# Altium schematic sheet 6_Axis.SchDoc
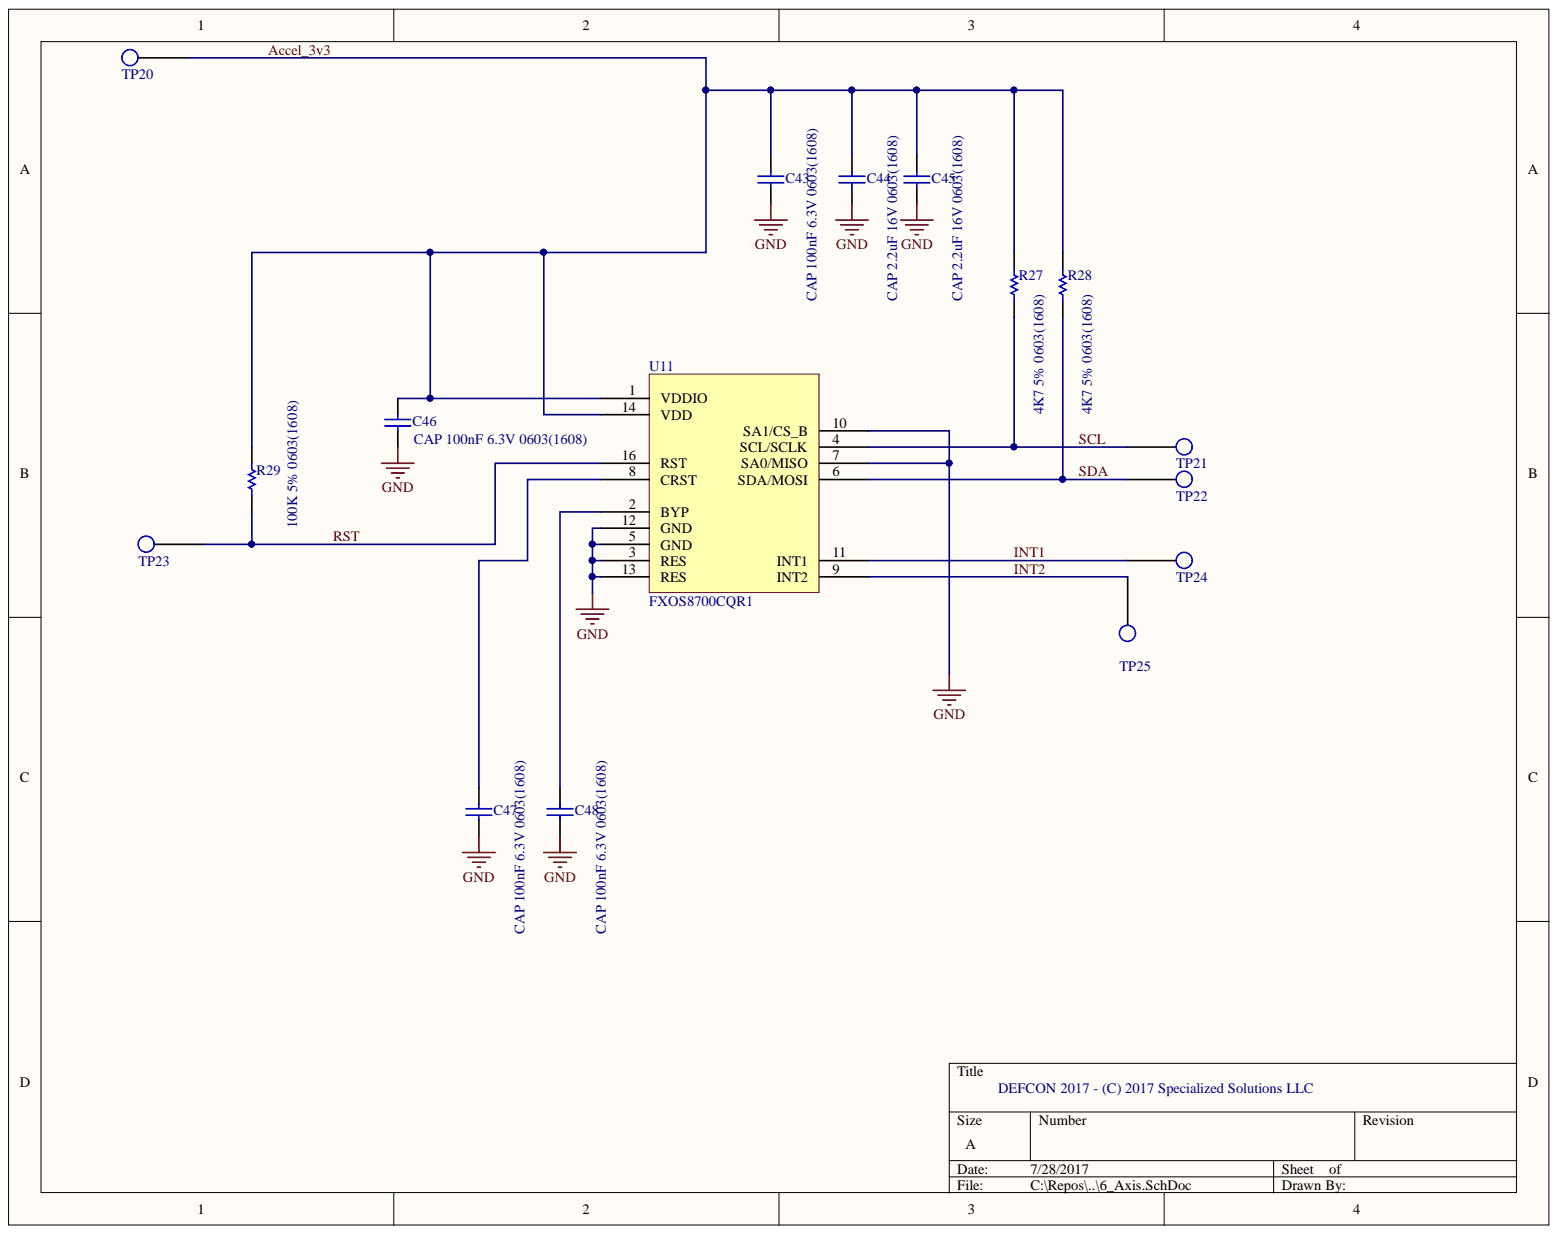
[diagram p0: 6_Axis.SchDoc]

## Parts
C43  CAP 100nF 6.3V 0603(1608)  value 100nF  [CAPC0603(1608)100_L]
C44  CAP 2.2uF 16V 0603(1608)  value 2.2uF  [CAPC0603(1608)55_L]
C45  CAP 2.2uF 16V 0603(1608)  value 2.2uF  [CAPC0603(1608)55_L]
C46  CAP 100nF 6.3V 0603(1608)  value 100nF  [CAPC0603(1608)100_L]
C47  CAP 100nF 6.3V 0603(1608)  value 100nF  [CAPC0603(1608)100_L]
C48  CAP 100nF 6.3V 0603(1608)  value 100nF  [CAPC0603(1608)100_L]
R27  4K7 5% 0603(1608)  [RESC0603(1608)_N]
R28  4K7 5% 0603(1608)  [RESC0603(1608)_N]
R29  100K 5% 0603(1608)  [RESC0603(1608)_N]
TP20  Test Point  [TP Castellation]  (value not drawn)  (no BOM)
TP21  Test Point  [TP Castellation]  (value not drawn)  (no BOM)
TP22  Test Point  [TP Castellation]  (value not drawn)  (no BOM)
TP23  Test Point  [TP Castellation]  (value not drawn)  (no BOM)
TP24  Test Point  [TP Castellation]  (value not drawn)  (no BOM)
TP25  Test Point  [TP Castellation]  (value not drawn)  (no BOM)
U11  FXOS8700CQR1  [QFN50P300X300X120-16N]

## Nets
GND: C43.1 C44.1 C45.1 C46.1 C47.1 C48.1 U11.3(RES) U11.5(GND) U11.7(SA0/MISO) U11.10(SA1/CS_B) U11.12(GND) U11.13(RES)
Accel_3v3: C43.2 C44.2 C45.2 C46.2 R27.2 R28.2 R29.2 TP20.1 U11.1(VDDIO) U11.14(VDD)
INT1: TP24.1 U11.11(INT1)
INT2: TP25.1 U11.9(INT2)
RST: R29.1 TP23.1 U11.16(RST)
SCL: R27.1 TP21.1 U11.4(SCL/SCLK)
SDA: R28.1 TP22.1 U11.6(SDA/MOSI)
NetC47_2: C47.2 U11.8(CRST)
NetC48_2: C48.2 U11.2(BYP)

## Library data of the parts
C43 C46-C48 = CMP-1035-02577-1: CAP 100nF 6.3V ±20% 0603 (1608 Metric) Thickness 1mm SMD; Case-EIA: 0603; Case-Metric: 1608; Tolerance: Â±20%; Component Kind: Standard; Library Name: Altium Content Vault; Max Thickness: 1 mm; Rated Voltage: 6.3 V; Technology: SMT
C44 C45 = CMP-1035-02764-1: CAP 2.2uF 16V ±20% 0603 (1608 Metric) Thickness 0.55mm SMD; Case-EIA: 0603; Case-Metric: 1608; Tolerance: Â±20%; Component Kind: Standard; Library Name: Altium Content Vault; Max Thickness: 0.55 mm; Rated Voltage: 16 V; Technology: SMT
  C44: Value: 2.2uF
R27 R28 = CMP-1012-00090-1: 4K7 0.1W 5% 0603 (1608 Metric)  SMD; Case-EIA: 0603; Case-Metric: 1608; Value: 4700.00 Ohm; Component Kind: Standard; Library Name: Altium Content Vault; Power: 0.1 W; Technology: SMT; Tolerance: 5 %
R29 = CMP-1012-00122-1: 100K 0.1W 5% 0603 (1608 Metric)  SMD; Case-EIA: 0603; Case-Metric: 1608; Value: 100000.00 Ohm; Component Kind: Standard; Library Name: Altium Content Vault; Power: 0.1 W; Technology: SMT; Tolerance: 5 %
TP20-TP25 = TP Castellation: Description: TP Castellation; Library Name: Parts.SchLib
U11 = FXOS8700CQR1: 6-axis sensor with integrated linear accelerometer and magnetometer; Component Kind: Standard; Library Name: Parts.SchLib
